# Altium schematic sheet PHY.SchDoc(PHY)
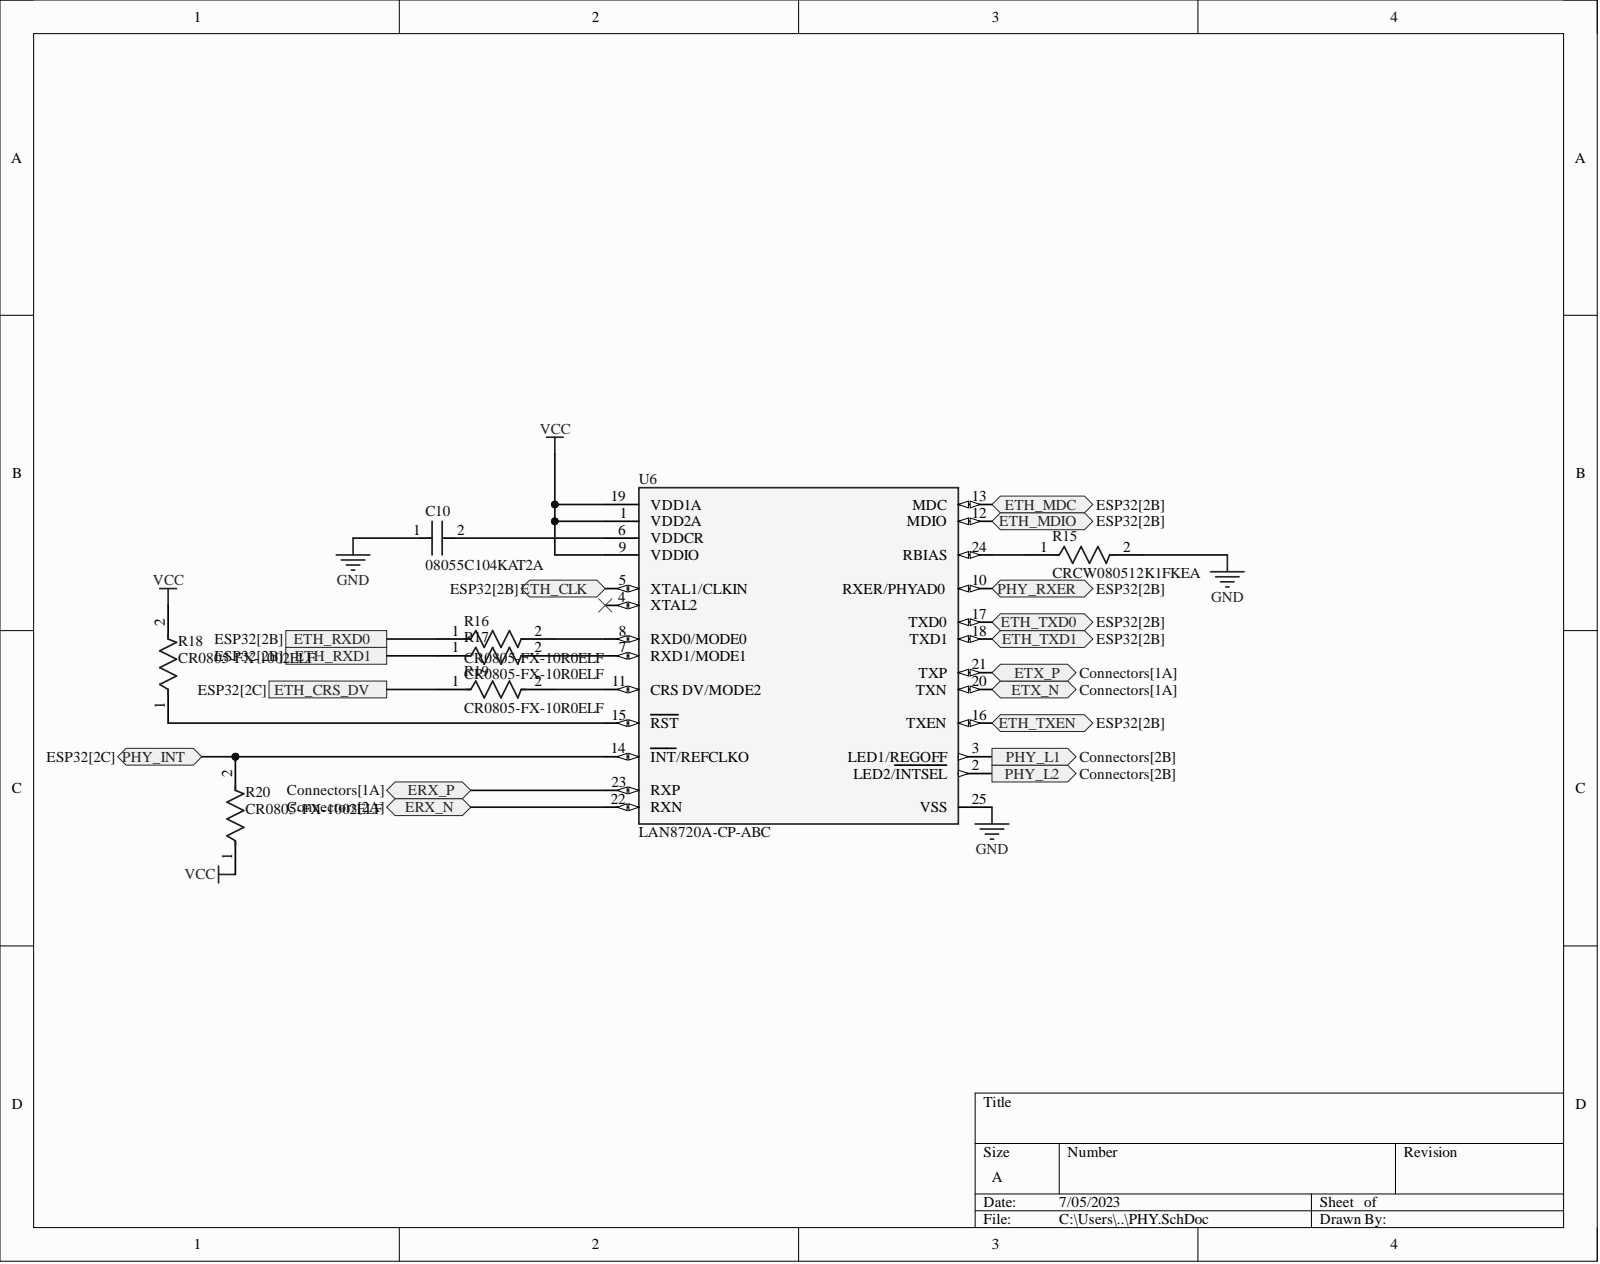
[diagram p0: PHY.SchDoc]

## Parts
C10  08055C104KAT2A  [FP-0805-L_2_01_0_2-W_1_25-IPC_C]
R15  CRCW080512K1FKEA  [FP-CRCW0805-e3-IPC_B]
R16  CR0805-FX-10R0ELF  [FP-CR0805-IPC_B]
R17  CR0805-FX-10R0ELF  [FP-CR0805-IPC_B]
R18  CR0805-FX-1002ELF  [FP-CR0805-IPC_A]
R19  CR0805-FX-10R0ELF  [FP-CR0805-IPC_B]
R20  CR0805-FX-1002ELF  [FP-CR0805-IPC_A]
U6  LAN8720A-CP-ABC  [FP-24-S4QFN-4x4B-0_5P-IPC_B]

## Ports
ERX_N, ERX_P, ETH_CLK, ETH_CRS_DV, ETH_MDC, ETH_MDIO, ETH_RXD0, ETH_RXD1, ETH_TXD0, ETH_TXD1, ETH_TXEN, ETX_N, ETX_P, PHY_INT, PHY_L1, PHY_L2, PHY_RXER

## Nets
GND: C10.1 R15.2 U6.25(VSS)
VCC: R18.2 R20.1 U6.1(VDD2A) U6.9(VDDIO) U6.19(VDD1A)
ERX_N: U6.22(RXN) ; port ERX_N
ERX_P: U6.23(RXP) ; port ERX_P
ETH_CLK: U6.5(XTAL1/CLKIN) ; port ETH_CLK
ETH_CRS_DV: R19.1 ; port ETH_CRS_DV
ETH_MDC: U6.13(MDC) ; port ETH_MDC
ETH_MDIO: U6.12(MDIO) ; port ETH_MDIO
ETH_RXD0: R16.1 ; port ETH_RXD0
ETH_RXD1: R17.1 ; port ETH_RXD1
ETH_TXD0: U6.17(TXD0) ; port ETH_TXD0
ETH_TXD1: U6.18(TXD1) ; port ETH_TXD1
ETH_TXEN: U6.16(TXEN) ; port ETH_TXEN
ETX_N: U6.20(TXN) ; port ETX_N
ETX_P: U6.21(TXP) ; port ETX_P
PHY_INT: R20.2 U6.14(INT/REFCLKO) ; port PHY_INT
PHY_L1: U6.3(LED1/REGOFF) ; port PHY_L1
PHY_L2: U6.2(LED2/INTSEL) ; port PHY_L2
PHY_RXER: U6.10(RXER/PHYAD0) ; port PHY_RXER
NetC10_2: C10.2 U6.6(VDDCR)
NetR15_1: R15.1 U6.24(RBIAS)
NetR16_2: R16.2 U6.8(RXD0/MODE0)
NetR17_2: R17.2 U6.7(RXD1/MODE1)
NetR18_1: R18.1 U6.15(RST)
NetR19_2: R19.2 U6.11(CRS_DV/MODE2)

Not connected: U6 4(XTAL2)

## Library data of the parts
C10 = CMP-2000-05016-2: General Purpose Ceramic Capacitor, 0805, 100nF, 10%, X7R, 0.15, 50V; Dissipation Factor: 2.5%; Insulation Resistance: 100GR; Capacitance: 100nF; Case Code (Imperial): 0805; Case Code (Metric): 2012; Case/Package: 0805; Component Kind: Standard; Depth: 1.25mm; Dielectric: X7R; Dielectric Material: Ceramic; Height: 0.5mm; Lead Free: Lead Free; Length: 2mm; Library Name: Altium Content Vault; Max Operating Temperature: 125°C; Min Operating Temperature: -55°C; Mount: Surface Mount; Number of Pins: 2; Package Quantity: 4000; Packaging: Cut Tape; Radiation Hardening: No; REACH SVHC: No SVHC; RoHS Compliant: Yes; Series: MLCC X7R; Termination: Standard; Thickness: 0.037inch; Tolerance: 10%; Voltage: 50V; Voltage Rating: 50V; Voltage Rating (DC): 50V; Weight: 0.001411oz; Width: 1.2mm
R15 = CMP-2001-04935-2: RES Thick Film, 12.1kΩ, 1%, 0.125W, 100ppm/°C, 0805; Max Power Dissipation: 125mW; Resistance: 12.1kR; Voltage Rating: 150V; Case Code (Imperial): 0805; Case Code (Metric): 2012; Case/Package: 0805; Component Kind: Standard; Composition: Thick Film; Contact Plating: Tin; Depth: 1.25mm; Features: Automotive AEC-Q200; Height: 0.45mm; Lead Free: Lead Free; Length: 2mm; Library Name: Altium Content Vault; Max Operating Temperature: 155°C; Military Standard: Not; Min Operating Temperature: -55°C; Number of Terminations: 2; Package Quantity: 10; Packaging: Tape and Reel; Power Rating: 125mW; Radiation Hardening: No; Temperature Coefficient: 100ppm/°C; Termination: SMD/SMT; Tolerance: 1%; Voltage Rating (DC): 150V; Weight: 0.000194oz; Width: 0.049inch
R16 R17 R19 = CMP-07231-004657-1: Thick Film Chip Resistors 0805 10Ω 0.125W 1% 100ppm/°C; Power Rating: 125mW; Resistance: 10R; Voltage Rating: 150V; Case Code (Imperial): 0805; Case Code (Metric): 2012; Case/Package: 0805; Component Kind: Standard; Composition: Thick Film; Contact Plating: Tin; Depth: 1.25mm; Height: 0.5mm; Length: 2mm; Library Name: Altium Content Vault; Max Operating Temperature: 155°C; Military Standard: Not; Min Operating Temperature: -55°C; Mount: Surface Mount; Number of Pins: 2; Package Quantity: 5000; Packaging: Tape and Reel; Radiation Hardening: No; REACH SVHC: No SVHC; Temperature Coefficient: 100ppm/°C; Termination: SMD/SMT; Thickness: 508um; Tolerance: 1%; Voltage Rating (DC): 150V; Width: 1.25mm
R18 R20 = CMP-07231-003204-1: Thick Film Chip Resistors 0805 10kΩ 0.125W 1% 100ppm/°C; Max Power Dissipation: 125mW; Resistance: 10kR; Voltage Rating: 150V; Voltage Rating (DC): 100V; Case Code (Imperial): 0805; Case Code (Metric): 2012; Case/Package: 0805; Component Kind: Standard; Composition: Thick Film; Contact Plating: Tin; Depth: 1.25mm; Features: Moisture Resistant; Height: 0.5mm; Length: 2mm; Library Name: Altium Content Vault; Max Operating Temperature: 155°C; Min Operating Temperature: -55°C; Mount: Surface Mount; Number of Terminations: 2; Package Quantity: 1; Packaging: Tape and Reel; Power Rating: 125mW; Radiation Hardening: No; REACH SVHC: No SVHC; Temperature Coefficient: 100ppm/°C; Termination: SMD/SMT; Thickness: 508um; Tolerance: 1%; Width: 1.25mm
U6 = CMP-06281-000057-1: IC TRANSCEIVER FULL 2/2 24SQFN; Component Kind: Standard; Library Name: Altium Content Vault
